# Altium schematic sheet POTS.SchDoc(POTS)
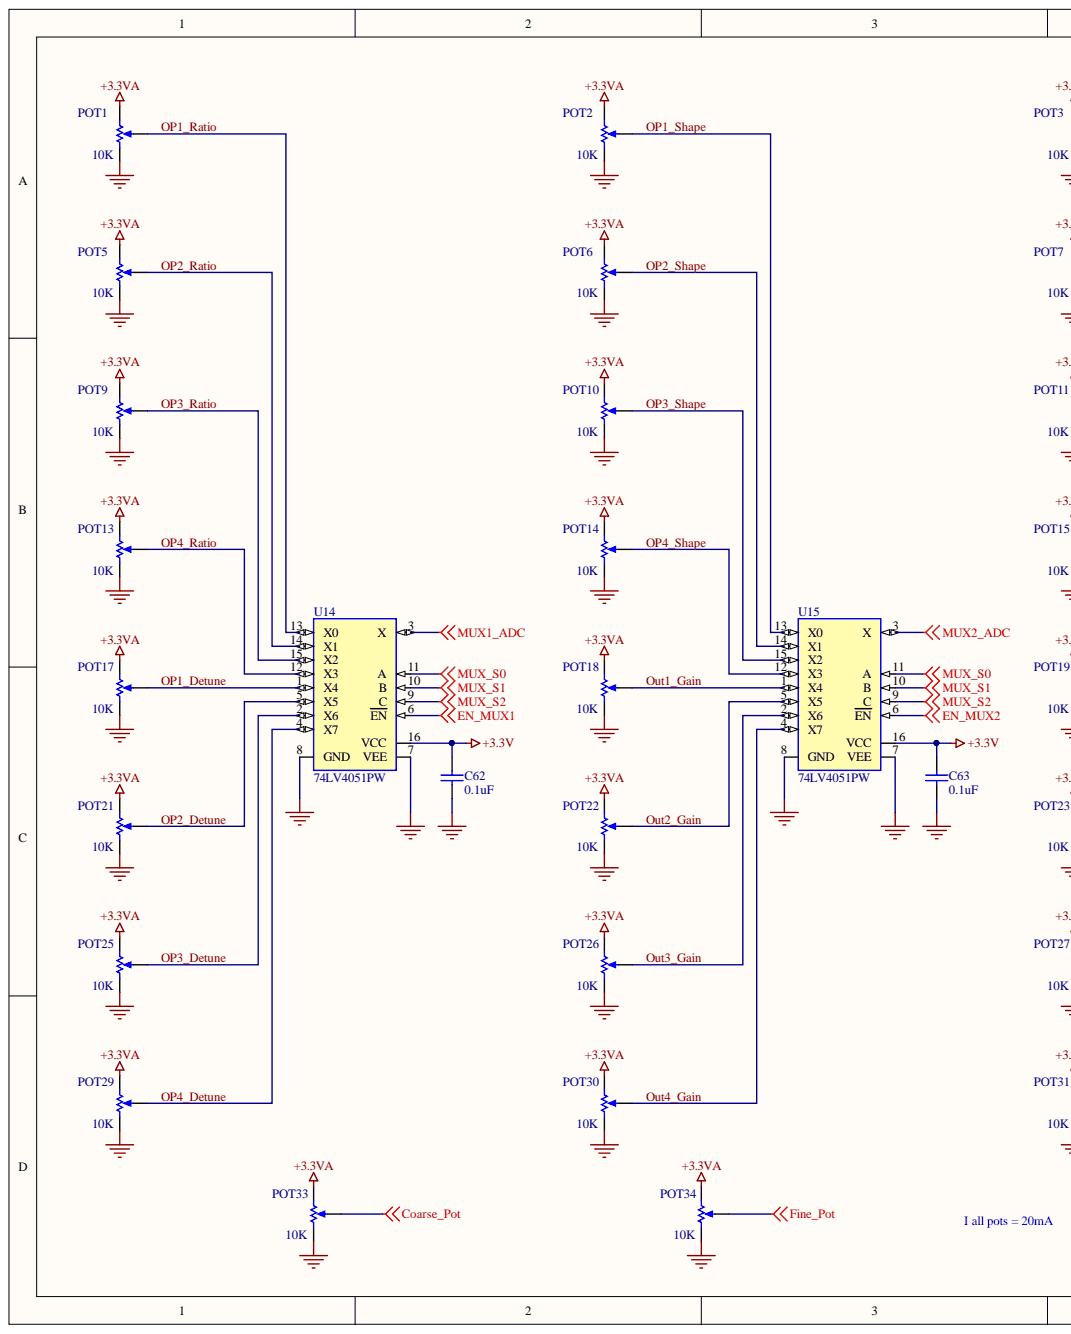
[diagram p0: POTS.SchDoc, left]
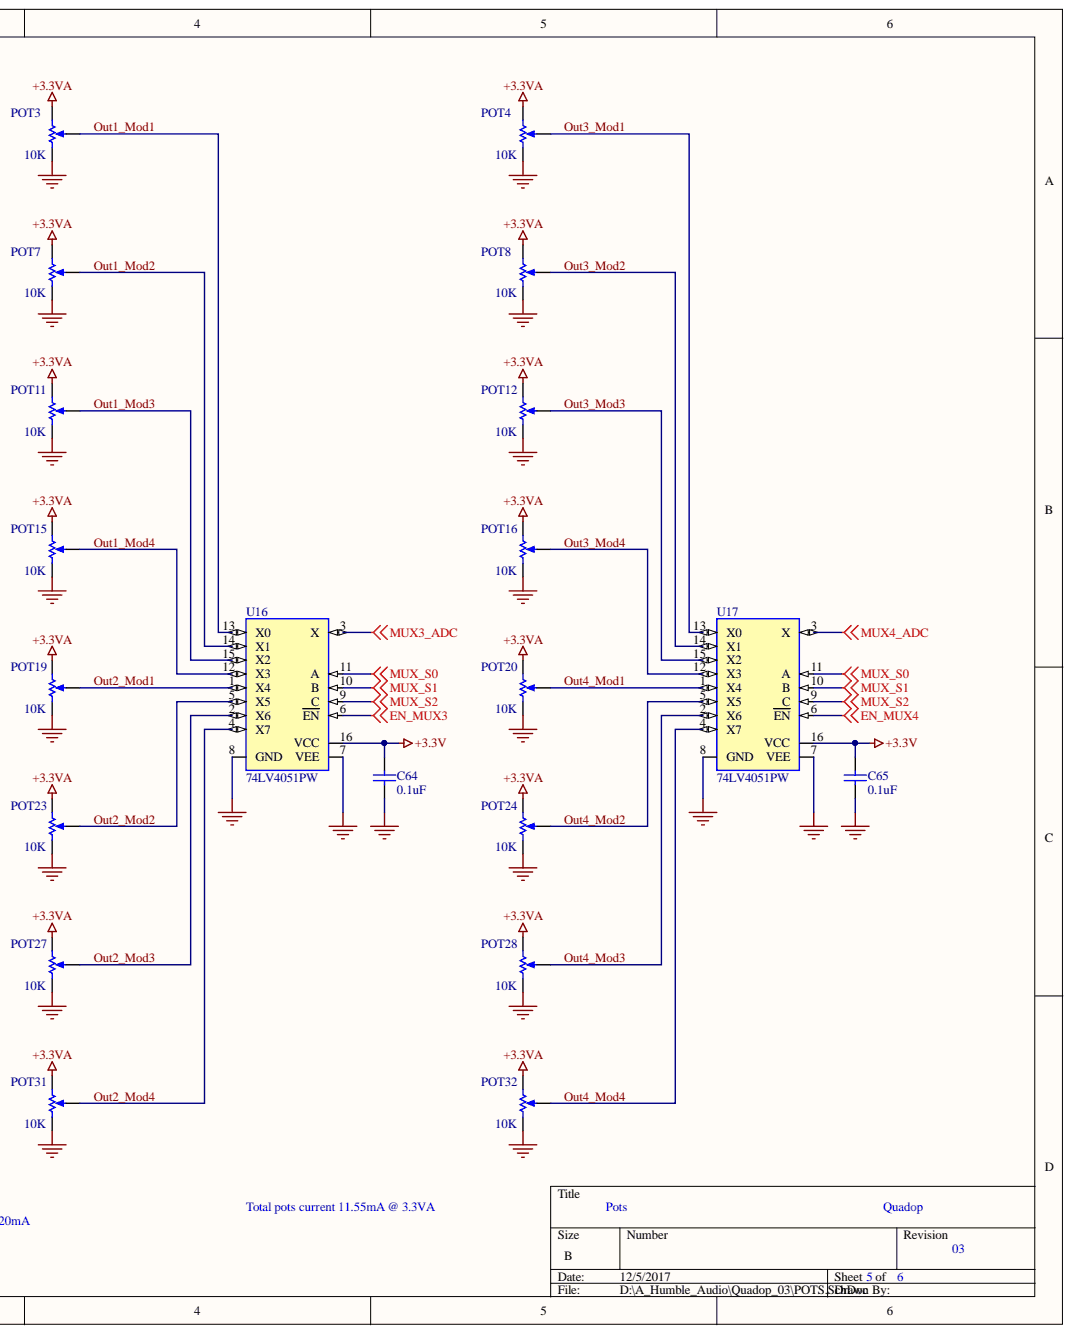
[diagram p1: POTS.SchDoc, right]

## Parts
C62  0.1uF  [C0603]
C63  0.1uF  [C0603]
C64  0.1uF  [C0603]
C65  0.1uF  [C0603]
POT1  10K  [POT_R0904N]
POT2  10K  [POT_R0904N]
POT3  10K  [POT_R0904N]
POT4  10K  [POT_R0904N]
POT5  10K  [POT_R0904N]
POT6  10K  [POT_R0904N]
POT7  10K  [POT_R0904N]
POT8  10K  [POT_R0904N]
POT9  10K  [POT_R0904N]
POT10  10K  [POT_R0904N]
POT11  10K  [POT_R0904N]
POT12  10K  [POT_R0904N]
POT13  10K  [POT_R0904N]
POT14  10K  [POT_R0904N]
POT15  10K  [POT_R0904N]
POT16  10K  [POT_R0904N]
POT17  10K  [POT_R0904N]
POT18  10K  [POT_R0904N]
POT19  10K  [POT_R0904N]
POT20  10K  [POT_R0904N]
POT21  10K  [POT_R0904N]
POT22  10K  [POT_R0904N]
POT23  10K  [POT_R0904N]
POT24  10K  [POT_R0904N]
POT25  10K  [POT_R0904N]
POT26  10K  [POT_R0904N]
POT27  10K  [POT_R0904N]
POT28  10K  [POT_R0904N]
POT29  10K  [POT_R0904N]
POT30  10K  [POT_R0904N]
POT31  10K  [POT_R0904N]
POT32  10K  [POT_R0904N]
POT33  10K  [POT_RV09ACF-40]
POT34  10K  [POT_RV09ACF-40]
U14  74LV4051PW  [TSSOP16_0.65/4.5X5.1]
U15  74LV4051PW  [TSSOP16_0.65/4.5X5.1]
U16  74LV4051PW  [TSSOP16_0.65/4.5X5.1]
U17  74LV4051PW  [TSSOP16_0.65/4.5X5.1]

## Nets
+3.3V: C62.1 C63.1 C64.1 C65.1 U14.16(VCC) U15.16(VCC) U16.16(VCC) U17.16(VCC)
+3.3VA: POT1.3 POT2.3 POT3.3 POT4.3 POT5.3 POT6.3 POT7.3 POT8.3 POT9.3 POT10.3 POT11.3 POT12.3 POT13.3 POT14.3 POT15.3 POT16.3 POT17.3 POT18.3 POT19.3 POT20.3 POT21.3 POT22.3 POT23.3 POT24.3 POT25.3 POT26.3 POT27.3 POT28.3 POT29.3 POT30.3 POT31.3 POT32.3 POT33.3 POT34.3
Coarse_Pot: POT33.2
EN_MUX1: U14.6(EN)
EN_MUX2: U15.6(EN)
EN_MUX3: U16.6(EN)
EN_MUX4: U17.6(EN)
Fine_Pot: POT34.2
GND: C62.2 C63.2 C64.2 C65.2 POT1.1 POT2.1 POT3.1 POT4.1 POT5.1 POT6.1 POT7.1 POT8.1 POT9.1 POT10.1 POT11.1 POT12.1 POT13.1 POT14.1 POT15.1 POT16.1 POT17.1 POT18.1 POT19.1 POT20.1 POT21.1 POT22.1 POT23.1 POT24.1 POT25.1 POT26.1 POT27.1 POT28.1 POT29.1 POT30.1 POT31.1 POT32.1 POT33.1 POT34.1 U14.7(VEE) U14.8(GND) U15.7(VEE) U15.8(GND) U16.7(VEE) U16.8(GND) U17.7(VEE) U17.8(GND)
MUX1_ADC: U14.3(X)
MUX2_ADC: U15.3(X)
MUX3_ADC: U16.3(X)
MUX4_ADC: U17.3(X)
MUX_S0: U14.11(A) U15.11(A) U16.11(A) U17.11(A)
MUX_S1: U14.10(B) U15.10(B) U16.10(B) U17.10(B)
MUX_S2: U14.9(C) U15.9(C) U16.9(C) U17.9(C)
OP1_Detune: POT17.2 U14.1(X4)
OP1_Ratio: POT1.2 U14.13(X0)
OP1_Shape: POT2.2 U15.13(X0)
OP2_Detune: POT21.2 U14.5(X5)
OP2_Ratio: POT5.2 U14.14(X1)
OP2_Shape: POT6.2 U15.14(X1)
OP3_Detune: POT25.2 U14.2(X6)
OP3_Ratio: POT9.2 U14.15(X2)
OP3_Shape: POT10.2 U15.15(X2)
OP4_Detune: POT29.2 U14.4(X7)
OP4_Ratio: POT13.2 U14.12(X3)
OP4_Shape: POT14.2 U15.12(X3)
Out1_Gain: POT18.2 U15.1(X4)
Out1_Mod1: POT3.2 U16.13(X0)
Out1_Mod2: POT7.2 U16.14(X1)
Out1_Mod3: POT11.2 U16.15(X2)
Out1_Mod4: POT15.2 U16.12(X3)
Out2_Gain: POT22.2 U15.5(X5)
Out2_Mod1: POT19.2 U16.1(X4)
Out2_Mod2: POT23.2 U16.5(X5)
Out2_Mod3: POT27.2 U16.2(X6)
Out2_Mod4: POT31.2 U16.4(X7)
Out3_Gain: POT26.2 U15.2(X6)
Out3_Mod1: POT4.2 U17.13(X0)
Out3_Mod2: POT8.2 U17.14(X1)
Out3_Mod3: POT12.2 U17.15(X2)
Out3_Mod4: POT16.2 U17.12(X3)
Out4_Gain: POT30.2 U15.4(X7)
Out4_Mod1: POT20.2 U17.1(X4)
Out4_Mod2: POT24.2 U17.5(X5)
Out4_Mod3: POT28.2 U17.2(X6)
Out4_Mod4: POT32.2 U17.4(X7)

## Library data of the parts
C62-C65 = 00000538: 100nF 50V +-10% X7R 0603 -55+125; Manufacturer Part Number: GRM188R71H104KA93J; Manufacturer: MURATA; PSpice: C; Lead time: Stock; Database Table Name: Capacitor; Voltage: 50V; Value: 0.1uF; Tolerance: +-10%; Tape Width: 8mm; SMD: Yes; Price: 0.0048; Part Type: Ceramic; Part Number: 00000538; Package: 10000T&R330mm; MOQ: 4000; Main distributor: Rutronik; Distributor: Rutronik; Component Kind: Standard; Availability: In Stock; ActivepartsID: http://search.murata.co.jp/Ceramy/GrsearchViewAction.do;jsessionid=C4D720578E4D2E51EC807AFF78C1B5C3; Library Name: Gedo_DB.DbLib
POT1-POT32 = 18005010: Potentiometer 10K, 9mm, mono,linear,9.7x12.5x25mm; Manufacturer Part Number: R0904N-B10K,L-25KQ; Manufacturer: Song Huei; Tolerance: 20%; PSpice: R; Power: 0.05W; Database Table Name: Resistor; Value: 10K; Price: 0.85; Part type: Trimmer; Part Number: 18005010; Distributor Part Number: R0904N-B10K,L-25KQ; Distributor: thonk.co.uk; Component Kind: Standard; Library Name: Gedo_DB.DbLib
POT33 POT34 = 18006010: Potentiometer 10K, 9mm, mono,linear,9.5x11.4x27mm; Manufacturer Part Number: P0915N-FC20BR10K; Manufacturer: BI Technologies / TT Electronics; Tolerance: 20%; PSpice: R; Power: 0.05W; Database Table Name: Resistor; Value: 10K; Price: 1.03; Part type: Trimmer; Part Number: 18006010; Distributor Part Number: 858-P0915N-FC20BR10K; Distributor: Mouser; Component Kind: Standard; Library Name: Gedo_DB.DbLib
U14-U17 = 50040513: Low voltage 1-6V 8-ch Analog Multiplex/Demultiplexer; 85OhmTSSOP16;-40+85; Manufacturer Part Number: 74LV4051PW,118; Manufacturer: NXP; Database Table Name: IC; Value: 74LV4051PW; SMD: Yes; Price: 0.561; Part Type: Logic; Part Number: 50040513; Package: 2500T&R; Distributor Part Number: 568-4486-1-ND; Distributor: Digi-Key; Component Kind: Standard; Library Name: Gedo_DB.DbLib
